# Altium schematic sheet Client_Node_1.SchDoc
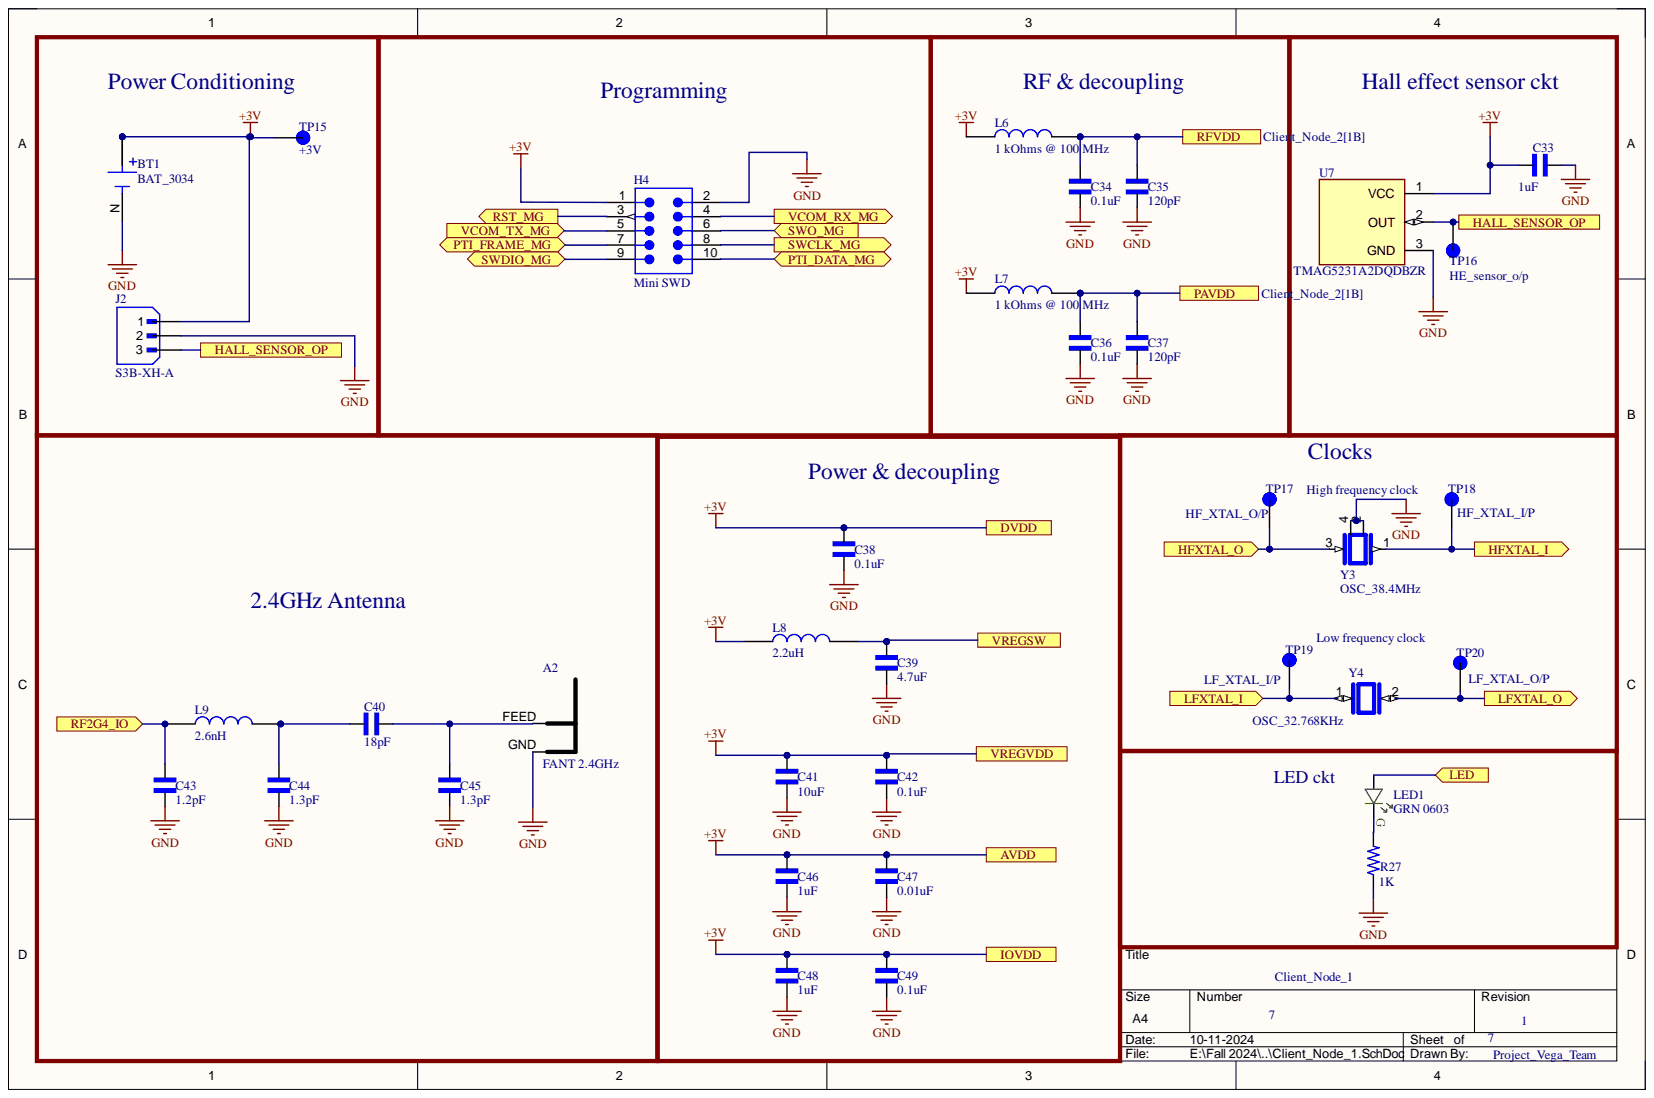
[diagram p0: Client_Node_1.SchDoc]

## Parts
A2  FANT 2.4GHz  [FANT_2P4]
BT1  BAT_3034  [BAT_3034]
C33  1uF  [CAPC1608X90]
C34  0.1uF  [CAPC1608X90]
C35  120pF  [CAPC1608X90]
C36  0.1uF  [CAPC1608X90]
C37  120pF  [CAPC1608X90]
C38  0.1uF  [CAPC1608X90]
C39  4.7uF  [CAPC1608X90]
C40  18pF  [CAPC1608X90]
C41  10uF  [CAPC1608X90]
C42  0.1uF  [CAPC1608X90]
C43  1.2pF  [CAPC1608X90]
C44  1.3pF  [CAPC1608X90]
C45  1.3pF  [CAPC1608X90]
C46  1uF  [CAPC1608X90]
C47  0.01uF  [CAPC1608X90]
C48  1uF  [CAPC1608X90]
C49  0.1uF  [CAPC1608X90]
H4  Mini SWD  [FTSH-105-01-XXX-DV-K]
J2  S3B-XH-A  [JST_S3B-XH-A]
L6  1 kOhms @ 100 MHz  [INDC1608X95N]
L7  1 kOhms @ 100 MHz  [INDC1608X95N]
L8  2.2uH  [INDC1608X95N]
L9  2.6nH  [INDC1608X95N]
LED1  GRN 0603  [LED_0603_GRN_WL-SMCW]
R27  1K  [RES_0603]
TP15  +3V  [TP]
TP16  HE_sensor_o/p  [TP]
TP17  HF_XTAL_O/P  [TP]
TP18  HF_XTAL_I/P  [TP]
TP19  LF_XTAL_I/P  [TP]
TP20  LF_XTAL_O/P  [TP]
U7  TMAG5231A2DQDBZR  [SOT95P237X112-3N]
Y3  OSC_38.4MHz  [OSC_38.4MHz]
Y4  OSC_32.768KHz  [OSC_32.768KHz]

## Ports
AVDD, DVDD, HALL_SENSOR_OP, HFXTAL_I, HFXTAL_O, IOVDD, LED, LFXTAL_I, LFXTAL_O, PAVDD, PTI_DATA_MG, PTI_FRAME_MG, RF2G4_IO, RFVDD, RST_MG, SWCLK_MG, SWDIO_MG, SWO_MG, VCOM_RX_MG, VCOM_TX_MG, VREGSW, VREGVDD

## Nets
GND: A2.GND BT1.N C33.1 C34.2 C35.2 C36.2 C37.2 C38.2 C39.2 C41.2 C42.2 C43.2 C44.2 C45.2 C46.2 C47.2 C48.2 C49.2 H4.2 J2.2 R27.1 U7.3 Y3.2 Y3.4
+3V: BT1.P1 BT1.P2 C33.2 C38.1 C41.1 C42.1 C46.1 C47.1 C48.1 C49.1 H4.1 J2.1 L6.1 L7.1 L8.1 TP15.TP U7.1 ; port AVDD, port DVDD, port IOVDD, port VREGVDD
HALL_SENSOR_OP: J2.3 TP16.TP U7.2 ; port HALL_SENSOR_OP
HFXTAL_I: TP18.TP Y3.1 ; port HFXTAL_I
HFXTAL_O: TP17.TP Y3.3 ; port HFXTAL_O
LED: LED1.A ; port LED
LFXTAL_I: TP19.TP Y4.1 ; port LFXTAL_I
LFXTAL_O: TP20.TP Y4.2 ; port LFXTAL_O
PAVDD: C36.1 C37.1 L7.2 ; port PAVDD
PTI_DATA_MG: H4.10 ; port PTI_DATA_MG
PTI_FRAME_MG: H4.7 ; port PTI_FRAME_MG
RF2G4_IO: C43.1 L9.1 ; port RF2G4_IO
RFVDD: C34.1 C35.1 L6.2 ; port RFVDD
RST_MG: H4.3 ; port RST_MG
SWCLK_MG: H4.8 ; port SWCLK_MG
SWDIO_MG: H4.9 ; port SWDIO_MG
SWO_MG: H4.6 ; port SWO_MG
VCOM_RX_MG: H4.4 ; port VCOM_RX_MG
VCOM_TX_MG: H4.5 ; port VCOM_TX_MG
VREGSW: C39.1 L8.2 ; port VREGSW
NetA2_FEED: A2.FEED C40.2 C45.1
NetC40_1: C40.1 C44.1 L9.2
NetLED1_C: LED1.C R27.2

## Library data of the parts
A2 = FANT_2P4: Inverted F antenna; Component Kind: Standard; Library Name: Project_Vega.SchLib
BT1 = BAT_3034: SMT Holder for 20mm Cell-Tin Nickel Plate; MF: Keystone Electronics Corp.; MP: 3034; Availability: Not in stock; Check_prices: https://www.snapeda.com/parts/3034/Keystone+Electronics/view-part/?ref=eda; Component Kind: Standard; Package: None; Price: None; SnapEDA_Link: https://www.snapeda.com/parts/3034/Keystone+Electronics/view-part/?ref=snap; Supplier 1: Digikey; Supplier Part Number 1: 36-3034-ND; Library Name: Project_Vega.IntLib
C33-C49 = CC0603KRX7R9BB104: 0.1 ÂµF Â±10% 50V Ceramic Capacitor X7R 0603 (1608 Metric); MF: Yageo; Check_prices: https://www.snapeda.com/parts/CC0603KRX7R9BB104/Yageo/view-part/?ref=eda; Component Kind: Standard; MP: CC0603KRX7R9BB104; Package: 0603 Yageo; SnapEDA_Link: https://www.snapeda.com/parts/CC0603KRX7R9BB104/Yageo/view-part/?ref=snap; Supplier 1: Digikey; Library Name: Project_Vega.IntLib
  C33 C38 C42 C46 C48 C49: Supplier Part Number 1: 445-12472-1-ND
  C34 C36: Supplier Part Number 1: 445-7456-1-ND
  C35 C37: Supplier Part Number 1: 399-C0603C121J5GACTUCT-ND
  C39: Supplier Part Number 1: 399-C0603C475K9PAC7867CT-ND
  C40: Supplier Part Number 1: 490-14486-1-ND
  C41: Supplier Part Number 1: 490-7202-1-ND
  C43: Supplier Part Number 1: 490-GRM0335C1H1R2WA01DCT-ND
  C44 C45: Supplier Part Number 1: 490-6120-1-ND
  C47: Supplier Part Number 1: 311-4054-1-ND
H4 = Debug Connector Mini SWD - 0.05": Mini SWD 0.05" Pitch Connector - 10 Pin SMT Box Header; Manufacturer: Adafruit Industries; Manufacturer Part Number: 4048; Category: Connectors; Component Kind: Standard; ComponentLink1Description: https://media.digikey.com/pdf/Data%20Sheets/Adafruit%20PDFs/4048_Web.pdf?src-supplier=Digi-Key; ComponentLink1URL: https://media.digikey.com/pdf/Data%20Sheets/Adafruit%20PDFs/4048_Web.pdf?src-supplier=Digi-Key; Library Name: Connectors.IntLib; Pricing 1: 1=1.95000 (USD); RoHS: No; Stock 1: 201; Supplier 1: Digikey; Supplier Part Number 1: 1528-2827-ND
J2 = S3B-XH-A: Connector Header Through Hole 3 position 0.098 (2.50mm); MF: JST Sales; MP: B3B-XH-A(LF)(SN); Check_prices: https://www.snapeda.com/parts/B3B-XH-A(LF)(SN)/JST+Sales+America+Inc./view-part/?ref=eda; Component Kind: Standard; Package: None; SnapEDA_Link: https://www.snapeda.com/parts/B3B-XH-A(LF)(SN)/JST+Sales+America+Inc./view-part/?ref=snap; Supplier 1: Digikey; Supplier Part Number 1: 455-S3B-XH-A-ND; Library Name: Project_Vega.IntLib
L6-L9 = LQM18DN100M70L: Inductor with Inductance 10uH Tol.+/-20% Package 0603 (1608); MF: Murata Electronics; Availability: Unavailable; Component Kind: Standard; MP: LQM18DN100M70L; Package: 0603 Murata; Price: None; SnapEDA_Link: https://www.snapeda.com/parts/LQM18DN100M70L/Murata/view-part/4834755/?ref=snap; Library Name: Project_Vega.IntLib
  L6: Supplier 1: Digikey; Supplier Part Number 1: 490-1015-1-ND
  L8: Supplier 1: Digikey; Supplier Part Number 1: 732-14076-1-ND
  L9: Supplier 1: Digikey; Supplier Part Number 1: 490-13907-1-ND
LED1 = LED_green: LED, 0603, GREEN, 430MCD, 520NM; Manufacturer: Wurth Electronics; Manufacturer Part Number: 150060GS75000; 3D Model: SMCW_0603.igs    SMCW_0603.stp    SMCW_0603.wrl; Current - Test: 20mA; Dynamic Catalog: 0603 Rectangle with Flat Top Chip LEDs; Lens Style/Size: Rectangle with Flat Top, 1.1mm x 0.7mm; Lens Type: Clear; Other Names: 732-4971-2; Viewing Angle: 140°; Voltage - Forward (Vf) (Typ): 3.2V; Wavelength - Peak: 515nm; Category: Optoelectronics; Color: Green; Component Kind: Standard; ComponentLink1Description: https://katalog.we-online.de/led/datasheet/150060GS75000.pdf?src-supplier=Digi-Key; ComponentLink1URL: https://katalog.we-online.de/led/datasheet/150060GS75000.pdf?src-supplier=Digi-Key; ComponentLink2Description: http://www.produktinfo.conrad.com/datenblaetter/750000-774999/759427-da-01-en-CHIPLED_0603_W_KL_GRUEN_150060GS75000.pdf?src-supplier=Conrad; ComponentLink2URL: http://www.produktinfo.conrad.com/datenblaetter/750000-774999/759427-da-01-en-CHIPLED_0603_W_KL_GRUEN_150060GS75000.pdf?src-supplier=Conrad; Family: LED Indication - Discrete; Height: 0.70mm; Millicandela Rating: 430mcd; Mounting Type: Surface Mount; Online Catalog: 0603 Rectangle with Flat Top Chip LEDs; Package / Case: 0603 (1608 Metric); Packaging: Tape & Reel (TR); Pricing 1: 1=0.14, 50=0.129, 100=0.11, 500=0.095 (USD); Series: WL-SMCW; Size / Dimension: 1.60mm L x 0.80mm W; Standard Package: 4,000; Stock 1: 34964; Supplier 1: Digi-Key; Supplier Part Number 1: 732-4971-1-ND; Wavelength - Dominant: 520nm; Library Name: Project_Vega.IntLib
R27 = RES_0603: Thick film general purpose resistor 0603; MP: RC0603JR-070RL; Availability: Unavailable; Component Kind: Standard; Package: None; Price: None; Supplier 1: Digikey; Supplier Part Number 1: A106049CT-ND; Library Name: Project_Vega.IntLib
TP15-TP20 = Test_point: SMT Test Point- Mininature-1000 pc Reels; MF: Keystone Electronics; MP: 5019; Availability: In Stock; Component Kind: Standard; Package: None; Price: None; Purchase-URL: https://pricing.snapeda.com/search/part/5019/?ref=eda; Library Name: Project_Vega.IntLib
U7 = TMAG5231A2DQDBZR: SOT-23-3-Digital Switch Omnipolar Switch Push-Pull Hall Effect; MF: {'manufacturer': u'Texas Instruments'}; Availability: Unavailable; Component Kind: Standard; MP: TMAG5231A2DQDBZR; Package: None; Price: None; Supplier 1: Digikey; Supplier Part Number 1: 296-TMAG5231A2DQDBZRCT-ND; Library Name: Project_Vega.SchLib
Y3 = OSC_38.4MHz: 38.4 MHz ±10ppm Crystal 10pF 40 Ohms 4-SMD, No Lead; MF: {'manufacturer': u'Murata Electronics'}; MP: XRCGB38M400F2P00R0; Availability: Unavailable; Component Kind: Standard; Package: None; Price: None; Supplier 1: Digikey; Supplier Part Number 1: 4606-TZ3398BCT-ND; Library Name: Project_Vega.IntLib
Y4 = OSC_32.768KHz: Tuning Fork Crystal 32.768kHz in 1.2 x 2.0mm package; MF: Micro Crystal; MP: CM8V-T1A-32.768KHZ-7PF-20PPM-TA-QC; Availability: Not in stock; Check_prices: https://www.snapeda.com/parts/CM8V-T1A-32.768KHZ-7PF-20PPM-TA-QC/Micro+Crystal/view-part/?ref=eda; Component Kind: Standard; Package: SMD-2 Micro Crystal; Price: None; SnapEDA_Link: https://www.snapeda.com/parts/CM8V-T1A-32.768KHZ-7PF-20PPM-TA-QC/Micro+Crystal/view-part/?ref=snap; Supplier 1: Digikey; Supplier Part Number 1: 2195-CM8V-T1A-32.768KHZ-7PF-20PPM-TA-QCCT-ND; Library Name: Project_Vega.IntLib
